# Altium schematic sheet Sheet2.SchDoc
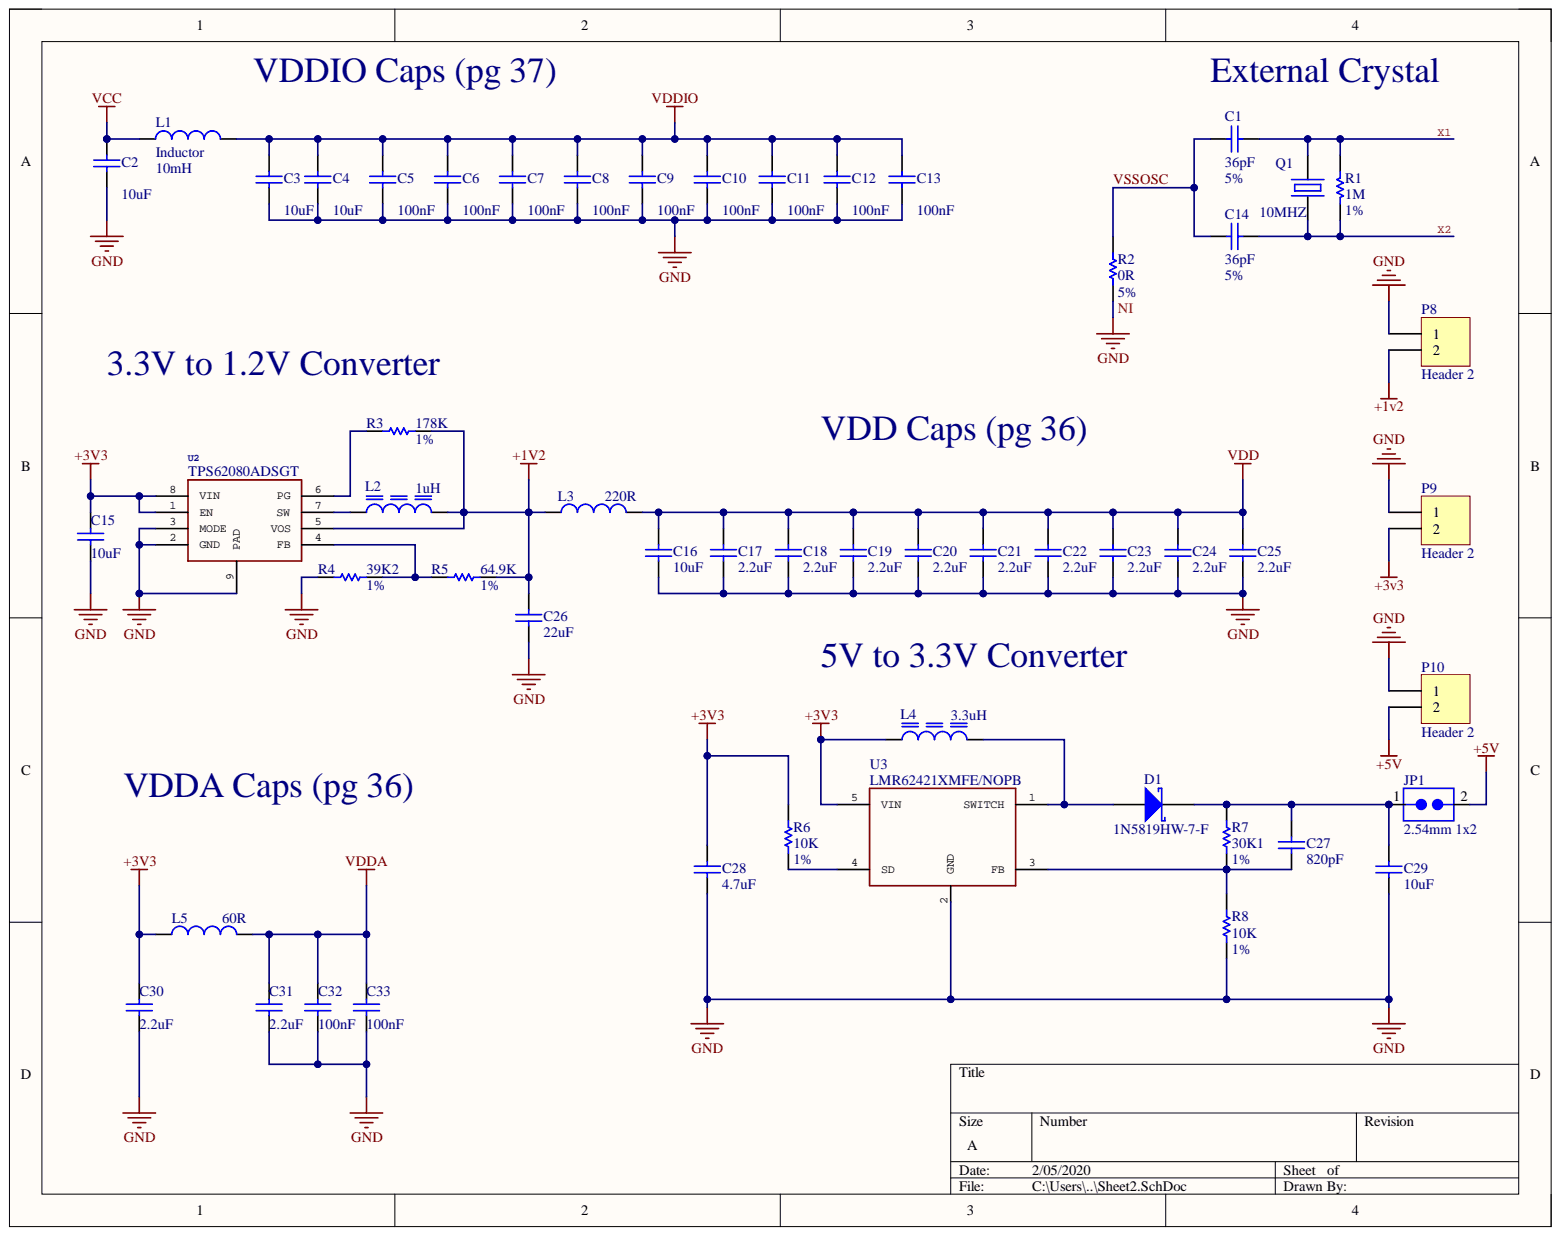
[diagram p0: Sheet2.SchDoc]

## Parts
C1  36pF  Rating: 5%  [C0402]
C2  value 10uF  [C1206]  (hidden comment: Cap Semi)
C3  value 10uF  [C1206]  (hidden comment: Cap Semi)
C4  value 10uF  [C1206]  (hidden comment: Cap Semi)
C5  value 100nF  [C1206]  (hidden comment: Cap Semi)
C6  value 100nF  [C1206]
C7  value 100nF  [C1206]  (hidden comment: Cap Semi)
C8  value 100nF  [C1206]  (hidden comment: Cap Semi)
C9  value 100nF  [C1206]  (hidden comment: Cap Semi)
C10  value 100nF  [C1206]
C11  value 100nF  [C1206]  (hidden comment: Cap Semi)
C12  value 100nF  [C1206]  (hidden comment: Cap Semi)
C13  value 100nF  [C1206]  (hidden comment: Cap Semi)
C14  36pF  Rating: 5%  [C0402]
C15  10uF  [C0603]
C16  10uF  [C0603]
C17  2.2uF  [C0402]
C18  2.2uF  [C0402]
C19  2.2uF  [C0402]
C20  2.2uF  [C0402]
C21  2.2uF  [C0402]
C22  2.2uF  [C0402]
C23  2.2uF  [C0402]
C24  2.2uF  [C0402]
C25  2.2uF  [C0402]
C26  22uF  [C0603]
C27  820pF  [C0402]
C28  4.7uF  [C0402]
C29  10uF  [C1210]
C30  2.2uF  [C0402]
C31  2.2uF  [C0402]
C32  100nF  [C0402]
C33  100nF  [C0402]
D1  1N5819HW-7-F  [SOD-123]
JP1  value 2.54mm 1x2  [HDRV2W64P254_1X2_508X250X850P]  (hidden comment: P6E02A-602530-B1)
L1  Inductor  value 10mH  [0402-A]
L2  1uH  [INDC3030X12N]
L3  220R  [L0402]
L4  3.3uH  [INDC380X380X165N]
L5  60R  [L0402]
P8  Header 2  [HDR1X2]
P9  Header 2  [HDR1X2]
P10  Header 2  [HDR1X2]
Q1  value 10MHZ  [OSC488P1105X465X350-2N]  (hidden comment: ATS100B-E)
R1  1M  Rating: 1%  [R0402]
R2  0R  ASSY: NI  Rating: 5%  [R0402]
R3  178K  Rating: 1%  [R0402]
R4  39K2  Rating: 1%  [R0402]
R5  64.9K  Rating: 1%  [R0402]
R6  10K  Rating: 1%  [R0402]
R7  30K1  Rating: 1%  [R0402]
R8  10K  Rating: 1%  [R0402]
U2  TPS62080ADSGT  [WSON50P200X80_HS-9N]
U3  LMR62421XMFE/NOPB  [SOT23-5]

## Nets
+1v2: C26.2 L2.2 L3.1 P8.2 R3.2 R5.2 U2.5(VOS)
+3v3: C15.2 C28.2 C30.2 L4.1 L5.1 P9.2 R6.1 U2.1(EN) U2.8(VIN) U3.5(VIN)
+5V: JP1.2 P10.2
GND: C2.1 C3.1 C4.1 C5.1 C6.1 C7.1 C8.1 C9.1 C10.1 C11.1 C12.1 C13.1 C15.1 C16.1 C17.1 C18.1 C19.1 C20.1 C21.1 C22.1 C23.1 C24.1 C25.1 C26.1 C28.1 C29.2 C30.1 C31.1 C32.1 C33.1 P8.1 P9.1 P10.1 R2.2 R4.1 R8.2 U2.2(GND) U2.3(MODE) U2.9(PAD) U3.2(GND)
VCC: C2.2 L1.1
VDD: C16.2 C17.2 C18.2 C19.2 C20.2 C21.2 C22.2 C23.2 C24.2 C25.2 L3.2
VDDA: C31.2 C32.2 C33.2 L5.2
VDDIO: C3.2 C4.2 C5.2 C6.2 C7.2 C8.2 C9.2 C10.2 C11.2 C12.2 C13.2 L1.2
VSSOSC: C1.2 C14.2 R2.1
X1: C1.1 Q1.2 R1.2
X2: C14.1 Q1.1 R1.1
NetC27_1: C27.1 R7.2 R8.1 U3.3(FB)
NetC27_2: C27.2 C29.1 D1.1 JP1.1 R7.1
NetD1_2: D1.2 L4.2 U3.1(SWITCH)
NetL2_1: L2.1 U2.7(SW)
NetR3_1: R3.1 U2.6(PG)
NetR4_2: R4.2 R5.1 U2.4(FB)
NetR6_2: R6.2 U3.4(SD)

## Library data of the parts
C1 C14 = 1004001100255: CAP,36pF,+/-5%,C0G,50V,SMD0402; Manufacturer: MURATA; Manufacturer Part Number: GRM1555C1H360JA01D; Database Table Name: Capacitor$; Component Kind: Standard; Embest PN: 1004001100255; Part Type: Ceramic Capacitor; Value: 36pF; Library Name: Embest.DbLib
C2-C13 = Cap Semi: Capacitor (Semiconductor SIM Model); Component Kind: Standard; LatestRevisionDate: 29-May-2009; LatestRevisionNote: IPC-7351 Footprint Added.; Library Name: Miscellaneous Devices.IntLib; PackageDescription: Chip Capacitor; PackageReference: C1206; Published: 8-Jun-2000; Signal Integrity: Cap Semi; Simulation: CAP; Publisher: Altium Limited
C15 C16 = 1004001100128: CAP,10UF,+/-20%,X5R,6.3V,SMD0603; Manufacturer: MURATA; Manufacturer Part Number: GRM188R60J106ME47D; Database Table Name: Capacitor$; Rating: 20%; Component Kind: Standard; Embest PN: 1004001100128; Part Type: Ceramic Capacitor; Value: 10uF; Library Name: Embest.DbLib
C17-C25 C30 C31 = 1004001100059: CAP,2.2UF,+/-20%,X5R,6.3V,SMD0402; Manufacturer: MURATA; Manufacturer Part Number: GRM155R60J225ME15D; Database Table Name: Capacitor$; Rating: 20%; Component Kind: Standard; Embest PN: 1004001100059; Part Type: Ceramic Capacitor; Value: 2.2uF; Library Name: Embest.DbLib
C26 = 1004001100130: CAP,22UF,+/-20%,X5R,6.3V,SMD0603; Manufacturer: MURATA; Manufacturer Part Number: GRM188R60J226MEA0D; Database Table Name: Capacitor$; Rating: 20%; Component Kind: Standard; Embest PN: 1004001100130; Part Type: Ceramic Capacitor; Value: 22uF; Library Name: Embest.DbLib
C27 = 1004001100029: CAP,820PF,+/-5%,C0G,50V,SMD0402; Manufacturer: MURATA; Manufacturer Part Number: GRM1555C1H821JA01D; Database Table Name: Capacitor$; Rating: 5%; Component Kind: Standard; Embest PN: 1004001100029; Part Type: Ceramic Capacitor; Value: 820pF; Library Name: Embest.DbLib
C28 = 1004001100218: CAP,4.7UF,+/-20%,X5R,6.3V,SMD0402 fix PN add D（20151023）; Manufacturer: MURATA; Manufacturer Part Number: GRM155R60J475ME47D; Database Table Name: Capacitor$; Rating: 20%; Component Kind: Standard; Embest PN: 1004001100218; Part Type: Ceramic Capacitor; Value: 4.7uF; Library Name: Embest.DbLib
C29 = 1004001100183: CAP,10UF,+/-10%,X7R,25V,SMD1210; Manufacturer: MURATA; Manufacturer Part Number: GRM32DR71E106KA12L; Database Table Name: Capacitor$; Rating: 10%; Component Kind: Standard; Embest PN: 1004001100183; Part Type: Ceramic Capacitor; Value: 10uF; Library Name: Embest.DbLib
C32 C33 = 1004001100187: CAP,100NF(0.1uf),+/-10%,X5R,10V,SMD0402; Manufacturer: MURATA; Manufacturer Part Number: GRM155R61A104KA01D; Database Table Name: Capacitor$; Rating: 10%; Component Kind: Standard; Embest PN: 1004001100187; Part Type: Ceramic Capacitor; Value: 100nF; Library Name: Embest.DbLib
D1 = 1006002100003: Diode,Schottky Diode,1N5819HW-7-F,40V,1A,SOD-123,SMD,-65~125,TR; Manufacturer: DIODES; Database Table Name: Diode$; Component Kind: Standard; Embest PN: 1006002100003; Manufacturer Part Number: 1N5819HW-7-F; Part Type: Diode; Rating: 1A; Value: 1N5819HW-7-F; Library Name: Embest.DbLib
JP1 = 1009001200003: Connector,Pin Header,Straight,Male,1x2Pin,2.54MM pitch,6.00,3.00,Gold Flash 1u, black,DIP,alt_code:15071705; Manufacturer: CHIN-BAN; Database Table Name: Connector$; Component Kind: Standard; Embest PN: 1009001200003; Manufacturer Part Number: P6E02A-602530-B1; Part Type: Pin Header; Library Name: Embest.DbLib
L1 = Inductor: Code_IEC: 1005; Code_JEITA: 0402; Component Kind: Standard; Description: Inductor; LatestRevisionDate: 29-May-2009; LatestRevisionNote: IPC-7351 Footprint Added.; Library Name: Miscellaneous Devices.IntLib; PackageDescription: Chip Inductor; PackageReference: 0402-A; Published: 8-Jun-2000; Signal Integrity: Inductor; Simulation: INDUCTOR; Publisher: Altium Limited
L2 = 1005001100053: FIXED IND 1UH 1.62A 40 MOHM SMD,+/-30%; Manufacturer: MURATA; Manufacturer Part Number: LQH3NPN1R0NJ0L; Database Table Name: Inductor$; Component Kind: Standard; Embest PN: 1005001100053; Part Type: Inductor; Rating: 1.62A; Value: 1uH; Library Name: Embest.DbLib
L3 = 1005005100064: FERRITE BEAD 220 OHM 0402,+/-25%; Manufacturer: Taiyo Yuden; Manufacturer Part Number: BKP1005EM221-T; Database Table Name: Inductor$; Component Kind: Standard; Embest PN: 1005005100064; Part Type: Ferrite Bead; Value: 220R; Library Name: Embest.DbLib
L4 = 1005004100014: Power Inductor,Magnetic shielded,3.3uH,1.4A,0.085ohm,3.8X3.8X1.6mm,SMD; Manufacturer: SUMIDA; Manufacturer Part Number: CDRH3D16/HPNP-3R3NC; Database Table Name: Inductor$; Component Kind: Standard; Embest PN: 1005004100014; Part Type: Inductor; Rating: 1.4A; Value: 3.3uH; Library Name: Embest.DbLib
L5 = 1005005100005: Ferrite Bead,60ohm@100MHz,+/-25%,1700mA,0.06ohm,SMD0402; Manufacturer: MURATA; Manufacturer Part Number: BLM15PD600SN1D; Database Table Name: Inductor$; Component Kind: Standard; Embest PN: 1005005100005; Part Type: Ferrite Bead; Rating: 1700mA; Value: 60R; Library Name: Embest.DbLib
P8-P10 = Header 2: Header, 2-Pin; Component Kind: Standard; LatestRevisionDate: 17-Jul-2002; LatestRevisionNote: Re-released for DXP Platform.; Library Name: Miscellaneous Connectors.IntLib; Signal Integrity: Connector; Publisher: Altium Limited
Q1 = 1008002200125: Crystal 10.0000MHz 30ppm 18pF 60 Ohm -40°C - 85°C Through Hole HC49/US; Manufacturer: CTS; Database Table Name: Osc_Xtal$; Component Kind: Standard; Embest PN: 1008002200125; Manufacturer Part Number: ATS100B-E; Part Type: Crystal; Library Name: Embest.DbLib
R1 = 1003001100155: RES,1M,+/-1%,1/16W,SMD0402; Manufacturer: YAGEO; Manufacturer Part Number: RC0402FR-071ML; Database Table Name: Resistor$; Component Kind: Standard; Embest PN: 1003001100155; Part Type: Thick film Resistor; Value: 1M; Library Name: Embest.DbLib
R2 = 1003001100001: RES,0R,+/-5%,1/16W,SMD0402; Manufacturer: YAGEO; Manufacturer Part Number: RC0402JR-070RL; Database Table Name: Resistor$; Component Kind: Standard; Embest PN: 1003001100001; Part Type: Thick film Resistor; Value: 0R; Library Name: Embest.DbLib
R3 = 1003001100723: RES, 178K OHM, 1%, 1/10W, SMD0402; Manufacturer: PANASONIC; Manufacturer Part Number: ERJ-2RKF1783X; Database Table Name: Resistor$; Component Kind: Standard; Embest PN: 1003001100723; Part Type: Thick film Resistor; Value: 178K; Library Name: Embest.DbLib
R4 = 1003001100098: RES,39K2,+/-1%,1/16W,SMD0402; Manufacturer: YAGEO; Manufacturer Part Number: RC0402FR-0739K2L; Database Table Name: Resistor$; Component Kind: Standard; Embest PN: 1003001100098; Part Type: Thick film Resistor; Value: 39K2; Library Name: Embest.DbLib
R5 = 1003001100730: RES, 64.9K OHM, 1%, 1/10W, SMD0402; Manufacturer: PANASONIC; Manufacturer Part Number: ERJ-2RKF6492X; Database Table Name: Resistor$; Component Kind: Standard; Embest PN: 1003001100730; Part Type: Thick film Resistor; Value: 64.9K; Library Name: Embest.DbLib
R6 R8 = 1003001100071: RES,10K,+/-1%,1/16W,SMD0402; Manufacturer: YAGEO; Manufacturer Part Number: RC0402FR-0710KL; Database Table Name: Resistor$; Component Kind: Standard; Embest PN: 1003001100071; Part Type: Thick film Resistor; Value: 10K; Library Name: Embest.DbLib
R7 = 1003001100091: RES,30K1,+/-1%,1/16W,SMD0402; Manufacturer: YAGEO; Manufacturer Part Number: RC0402FR-0730K1L; Database Table Name: Resistor$; Component Kind: Standard; Embest PN: 1003001100091; Part Type: Thick film Resistor; Value: 30K1; Library Name: Embest.DbLib
U2 = 1002005100089C: IC REG BUCK SYNC ADJ 1.2A 8WSON; Manufacturer: TI; Database Table Name: IC$; Component Kind: Standard; Embest PN: 1002005100089C; Manufacturer Part Number: TPS62080ADSGT; Part Type: DC-DC; Value: TPS62080ADSGT; Library Name: Embest.DbLib
U3 = 1002005100073C: Conv DC-DC Single Step Up 2.7V to 5.5V 5-Pin SOT-23 T/R ,CUSTOMER SUPPLY; Manufacturer: TI; Database Table Name: IC$; Component Kind: Standard; Embest PN: 1002005100073C; Manufacturer Part Number: LMR62421XMFE/NOPB; Part Type: DC-DC; Value: LMR62421XMFE/NOPB; Library Name: Embest.DbLib
